# Altium schematic sheet LED Backlight Driver.SchDoc("LED Backlight Driver")
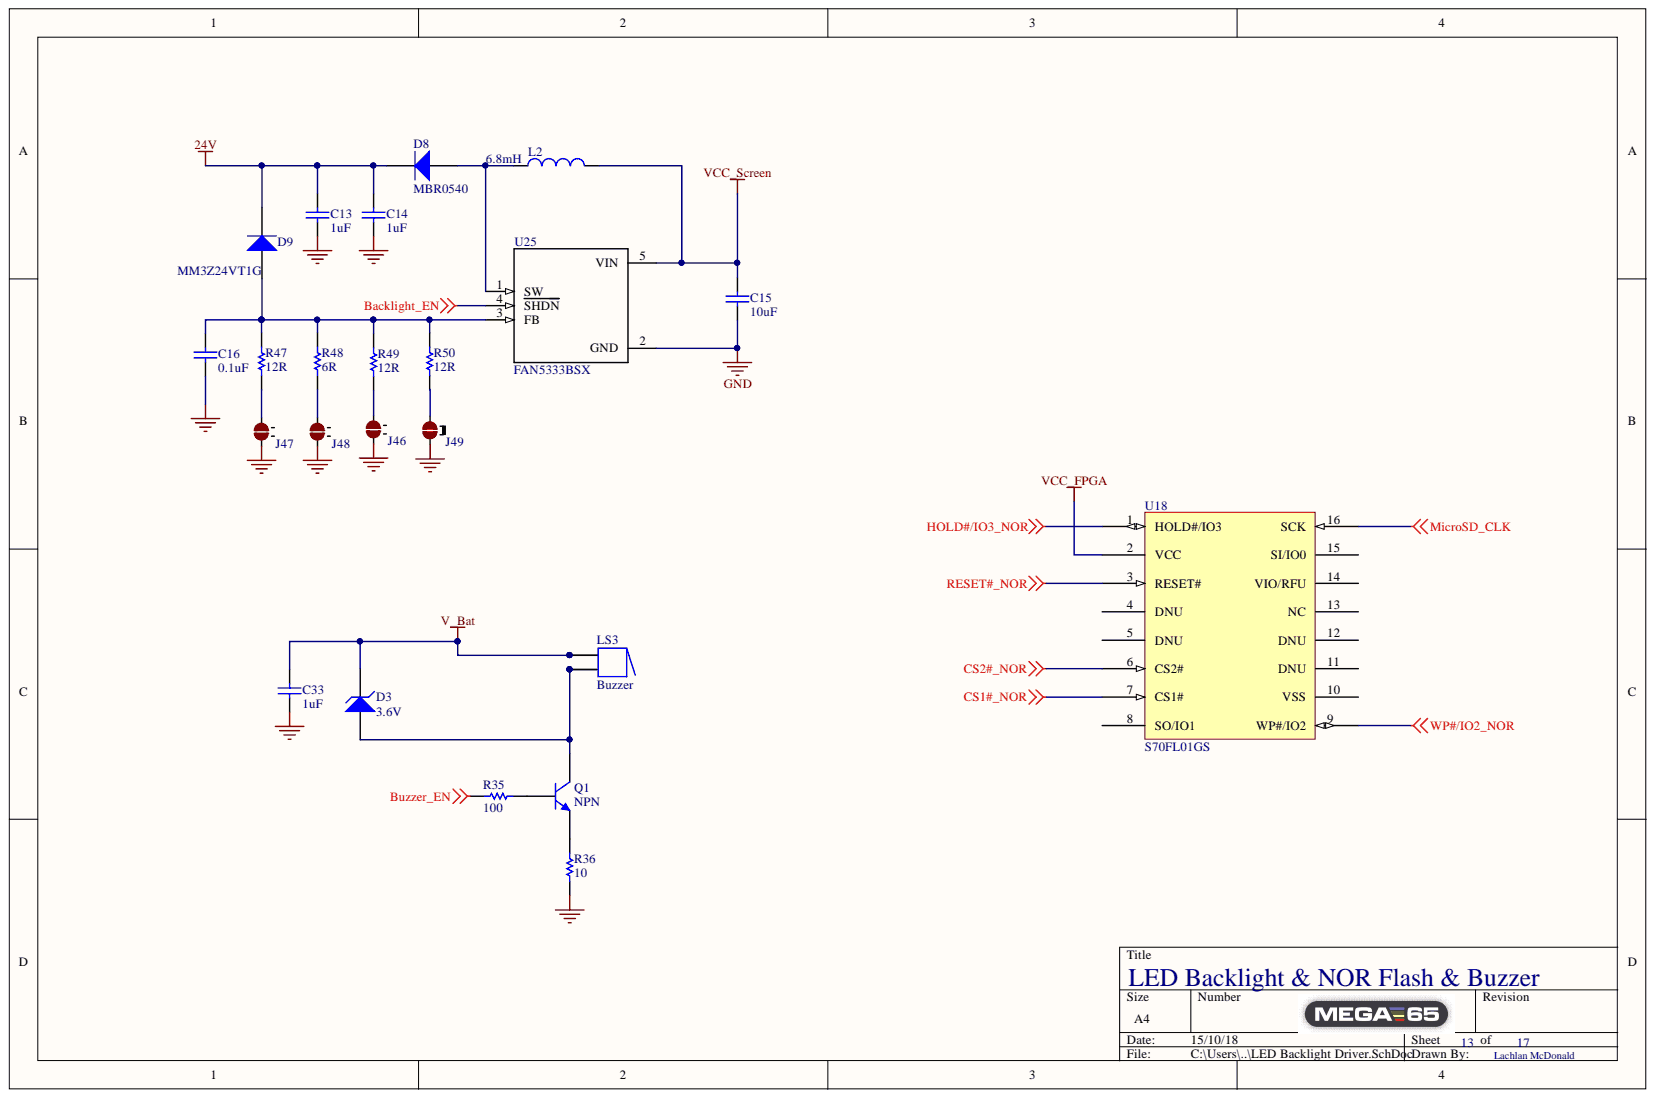
[diagram p0: LED Backlight Driver.SchDoc]

## Parts
C13  1uF  [CAPC0805(2012)100_N]
C14  1uF  [CAPC0805(2012)100_N]
C15  10uF  [CAPC0805(2012)100_N]
C16  0.1uF  [CAPC0805(2012)100_N]
C33  1uF  [CAPC0805(2012)100_N]
D3  3.6V  [6-0805_N]
D8  MBR0540  [SOD3716X135N]
D9  MM3Z24VT1G  [SOD3716X135N]
J46  2 way net solder junction - Normally Open  [2waySolder_NO]  (value not drawn)  (no BOM)
J47  2 way net solder junction - Normally Open  [2waySolder_NO]  (value not drawn)  (no BOM)
J48  2 way net solder junction - Normally Open  [2waySolder_NO]  (value not drawn)  (no BOM)
J49  2 way net solder junction - Normally Connected  [2waySolder_NC]  (value not drawn)  (no BOM)
L2  value 6.8mH  [0402-A]  (hidden comment: Inductor)
LS3  Buzzer  [Buzzer]
Q1  NPN  [TO-226-AA]
R35  100  [RESC0805(2012)_M]
R36  10  [RESC0805(2012)_M]
R47  12R  [RESC0805(2012)_M]
R48  6R  [RESC0805(2012)_M]
R49  12R  [RESC0805(2012)_M]
R50  12R  [RESC0805(2012)_M]
U18  S70FL01GS  [SOIC127P1030X265-16N]
U25  FAN5333BSX  [SOT95P280X145-5N]

## Nets
24V: C13.1 C14.2 D8.2 D9.2
Backlight_EN: U25.4(SHDN)
Buzzer_EN: R35.2
CS1#_NOR: U18.7(CS1#)
CS2#_NOR: U18.6(CS2#)
GND: C13.2 C14.1 C15.2 C16.2 C33.1 J46.1 J47.1 J48.1 J49.1 R36.1 U25.2(GND)
HOLD#/IO3_NOR: U18.1(HOLD#/IO3)
MicroSD_CLK: U18.16(SCK)
RESET#_NOR: U18.3(RESET#)
V_Bat: C33.2 D3.2 LS3.1 LS3.1A
VCC_FPGA: U18.2(VCC)
VCC_Screen: C15.1 L2.2 U25.5(VIN)
WP#/IO2_NOR: U18.9(WP#/IO2)
NetC16_1: C16.1 D9.1 R47.2 R48.2 R49.2 R50.2 U25.3(FB)
NetD3_1: D3.1 LS3.2 LS3.2A Q1.1
NetD8_1: D8.1 L2.1 U25.1(SW)
NetJ46_2: J46.2 R49.1
NetJ47_2: J47.2 R47.1
NetJ48_2: J48.2 R48.1
NetJ49_2: J49.2 R50.1
NetQ1_2: Q1.2 R35.1
NetQ1_3: Q1.3 R36.2

Not connected: U18 4(DNU) 5(DNU) 8(SO/IO1) 10(VSS) 11(DNU) 12(DNU) 13(NC) 14(VIO/RFU) 15(SI/IO0)

## Library data of the parts
C13-C16 C33 = CMP-1036-01531-1: CAP 39pF 100V ±2% 0805 (2012 Metric) Thickness 1mm SMD; Tolerance: Â±2%; Case-Metric: 2012; Case-EIA: 0805; Value: 39pF; Technology: SMT; Rated Voltage: 100 V; Max Thickness: 1 mm; Library Name: Altium Content Vault; Component Kind: Standard
D3 = D Zener: Zener Diode; Simulation: ZENER; Signal Integrity: D Zener; Published: 8-Jun-2000; PackageReference: DIODE-0.7; PackageDescription: Diode, Thru-Hole; 2 Leads; 0.7 in Pin Spacing; Library Name: Miscellaneous Devices.IntLib; LatestRevisionNote: Re-released for DXP Platform.; LatestRevisionDate: 17-Jul-2002; Component Kind: Standard; Publisher: Altium Limited
D8 D9 = Diode: Default Diode; Simulation: DIODE; Signal Integrity: Diode; Published: 8-Jun-2000; PackageVersion: Sep-1996; PackageReference: SMC; PackageDescription: DO-214-AB/SMC; 2 C-Bend Leads; Body 7.9 x 5.9 mm, inc. leads (LxW); Library Name: Miscellaneous Devices.IntLib; LatestRevisionNote: Re-released for DXP Platform.; LatestRevisionDate: 17-Jul-2002; Component Kind: Standard; Code_JEDEC: DO-214-AB; Publisher: Altium Limited
J46-J48 = 2waySolderNO: Library Name: NetTieLib.IntLib; Description: 2 way net solder junction - Normally Open; ComponentLink1URL: http://www.edatools.cz/addons; ComponentLink1Description: Source
J49 = 2waySolderNC: Library Name: NetTieLib.IntLib; Description: 2 way net solder junction - Normally Connected; ComponentLink1URL: http://www.edatools.cz/addons; ComponentLink1Description: Source
L2 = Inductor: Code_IEC: 1005; Simulation: INDUCTOR; Signal Integrity: Inductor; Published: 8-Jun-2000; PackageReference: 0402-A; PackageDescription: Chip Inductor; Library Name: Miscellaneous Devices.IntLib; LatestRevisionNote: IPC-7351 Footprint Added.; LatestRevisionDate: 29-May-2009; Description: Inductor; Component Kind: Standard; Code_JEITA: 0402; Publisher: Altium Limited
LS3 = Buzzer: Magnetic Transducer Buzzer; Published: 24-Mar-1999; PackageReference: ABSM-1574; PackageDescription: Magnetic Transducer Buzzer; Library Name: Miscellaneous Devices.IntLib; LatestRevisionNote: Schematic revised, PCB Footprint replaced.; LatestRevisionDate: 17-Dec-2003; DatasheetVersion: 17-Dec-2003; ComponentLink1URL: http://www.megastar.com/linecard/buzzmode/index.php; ComponentLink1Description: Manufacturer Link; Component Kind: Standard; Publisher: Altium Limited
Q1 = NPN: NPN Bipolar Transistor; Simulation: NPN; Signal Integrity: NPN; Published: 8-Jun-2000; PackageReference: TO-226-AA; PackageDescription: TO, Flat Index; 3 In-Line, Axial Leads; Body Dia. 4.8mm; Leads 0.55 x 0.51 mm (max); Library Name: Miscellaneous Devices.IntLib; LatestRevisionNote: Re-released for DXP Platform.; LatestRevisionDate: 17-Jul-2002; Component Kind: Standard; Code_JEDEC: TO-226-AA; Publisher: Altium Limited
R35 R36 R47-R50 = CMP-1013-00623-1: 10K 0.125W 1% 0805 (2012 Metric)  SMD; Value: 10000.00 Ohm; Case-Metric: 2012; Case-EIA: 0805; Tolerance: 1 %; Technology: SMT; Power: 0.125 W; Library Name: Altium Content Vault; Component Kind: Standard
U18 = NOR Flash: Library Name: Schlib1.SchLib; Component Kind: Standard
U25 = FAN5333BSX: FAN5333B Series 5.5 V 1.5 MHz High Efficiency High Current Serial LED Driver; MF: ON Semiconductor; SnapEDA_Link: https://www.snapeda.com/parts/FAN5333BSX/ON%20Semiconductor/view-part/?ref=snap; Price: 0.58 USD; Package: SOT-23-5 ON Semiconductor; MP: FAN5333BSX; Library Name: FAN5333BSX.IntLib; Component Kind: Standard; Availability: Good
